# Altium schematic sheet 超级电容V2.SchDoc
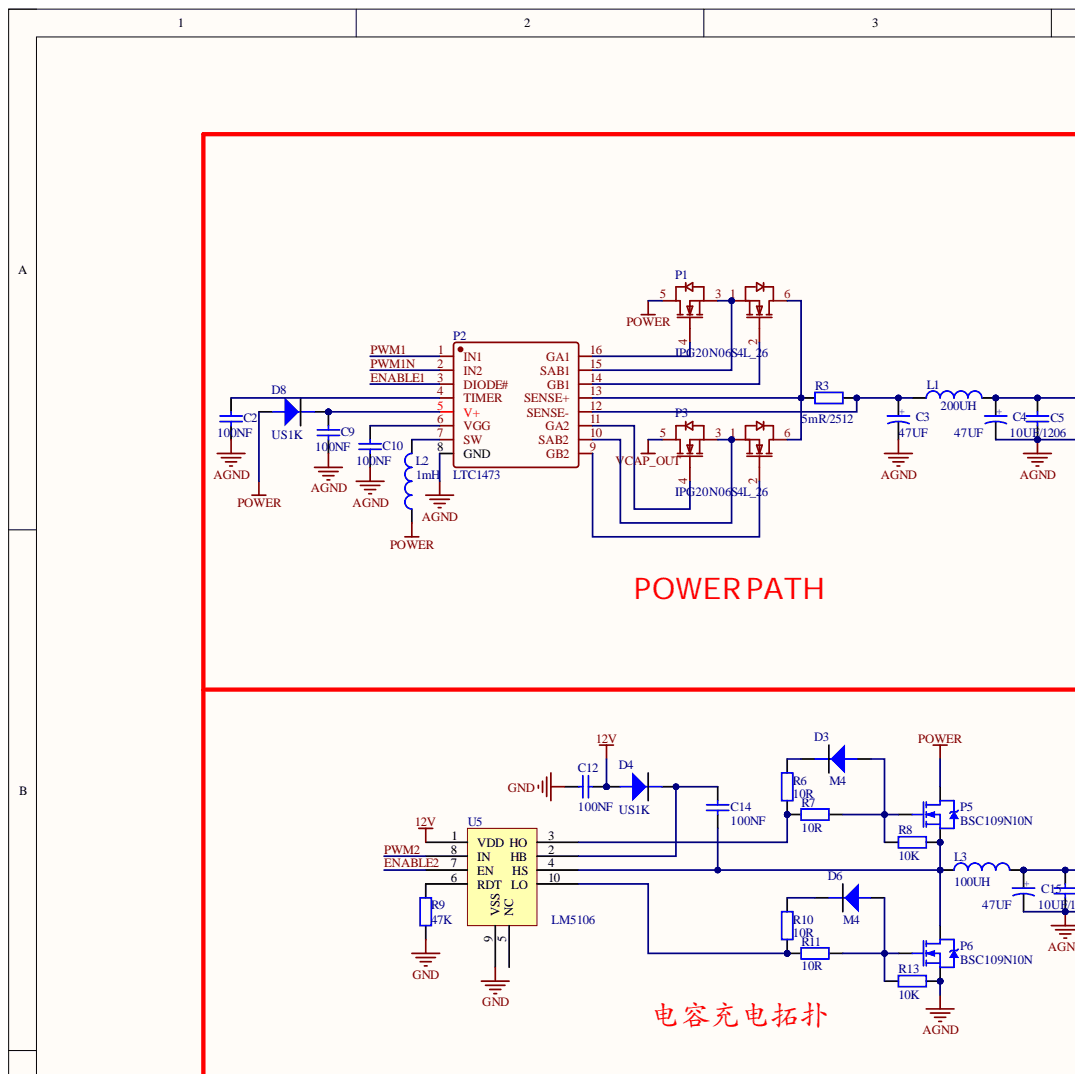
[diagram p0: 超级电容V2.SchDoc, top left]
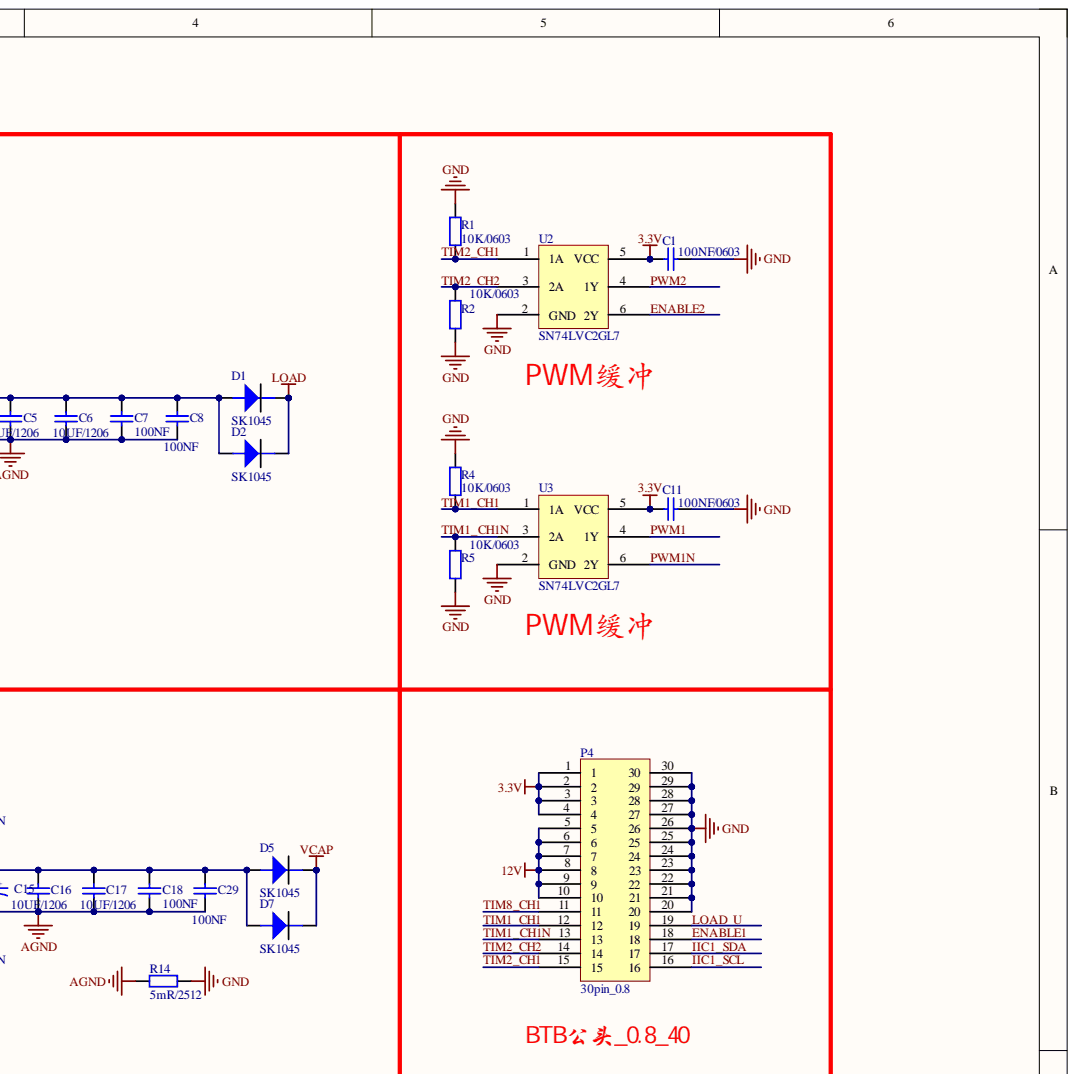
[diagram p1: 超级电容V2.SchDoc, top right]
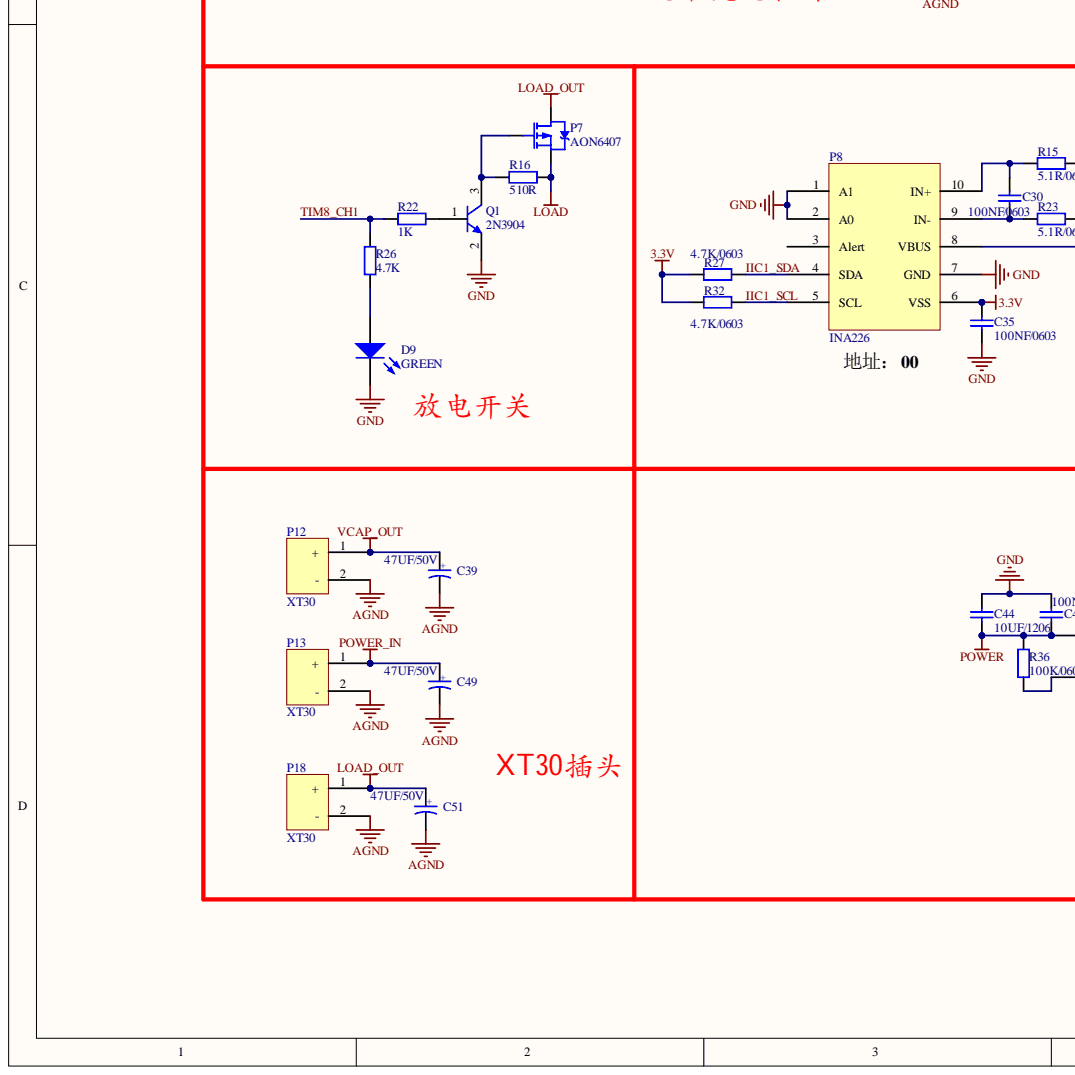
[diagram p2: 超级电容V2.SchDoc, bottom left]
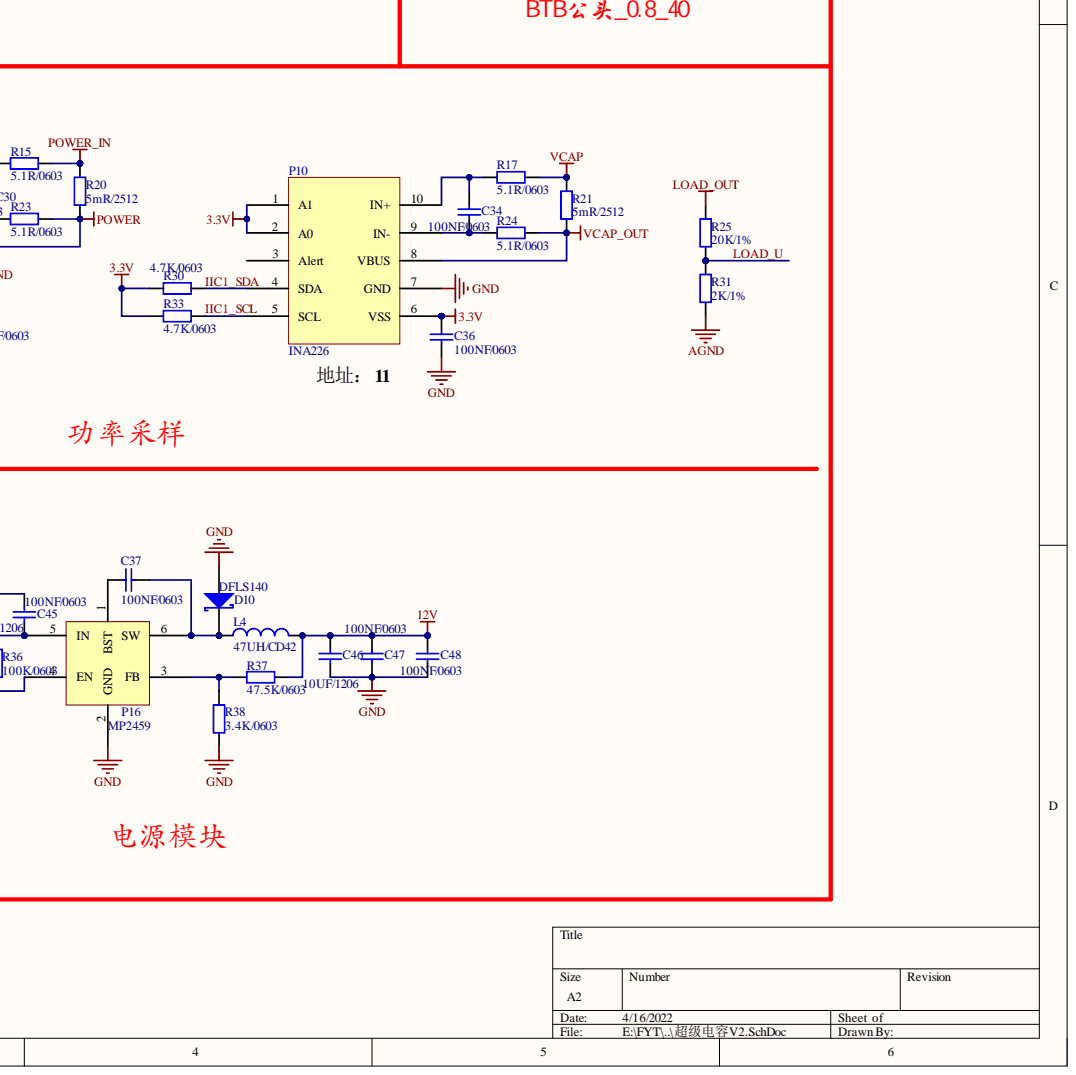
[diagram p3: 超级电容V2.SchDoc, bottom right]

## Parts
C1  100NF/0603  [C_0603]
C2  100NF  [C_0603]
C3  47UF  [C_1206]
C4  47UF  [C_1206]
C5  10UF/1206  [C_1206]
C6  10UF/1206  [C_1206]
C7  100NF  [C_0603]
C8  100NF  [C_0603]
C9  100NF  [C_0603]
C10  100NF  [C_0603]
C11  100NF/0603  [C_0603]
C12  100NF  [C_0603]
C14  100NF  [C_0603]
C15  47UF  [C_1206]
C16  10UF/1206  [C_1206]
C17  10UF/1206  [C_1206]
C18  100NF  [C_0603]
C29  100NF  [C_0603]
C30  100NF/0603  [C_0603]
C34  100NF/0603  [C_0603]
C35  100NF/0603  [C_0603]
C36  100NF/0603  [C_0603]
C37  100NF/0603  [C_0603]
C39  47UF/50V  [C-电解电容]
C44  10UF/1206  [C_1206]
C45  100NF/0603  [C_0603]
C46  10UF/1206  [C_1206]
C47  100NF/0603  [C_0603]
C48  100NF/0603  [C_0603]
C49  47UF/50V  [C-电解电容]
C51  47UF/50V  [C-电解电容]
D1  SK1045  [SK1045]
D2  SK1045  [SK1045]
D3  M4  [D_M4]
D4  US1K  [D-SMA]
D5  SK1045  [SK1045]
D6  M4  [D_M4]
D7  SK1045  [SK1045]
D8  US1K  [D-SMA]
D9  GREEN  [LED_0603]
D10  DFLS140  [D-SMA]
L1  200UH  [L_17X17]
L2  1mH  [L_1206]
L3  100UH  [L_17X17]
L4  47UH/CD42  [CD42]
P1  IPG20N06S4L_26  [IPG20N06S4L_26]
P2  LTC1473  [LTC1473]
P3  IPG20N06S4L_26  [IPG20N06S4L_26]
P4  30pin_0.8  [30PIN_0.8]
P5  BSC109N10N  [BSC109N10NS3G]
P6  BSC109N10N  [BSC109N10NS3G]
P7  AON6407  [BSC109N10NS3G]
P8  INA226  [INA226]
P10  INA226  [INA226]
P12  XT30  [30/卧式母]
P13  XT30  [30/卧式母]
P16  MP2459  [MP2459]
P18  XT30  [XT30卧母]
Q1  2N3904  [SOT-23_3]
R1  10K/0603  [R_0603]
R2  10K/0603  [R_0603]
R3  5mR/2512  [R_2512]
R4  10K/0603  [R_0603]
R5  10K/0603  [R_0603]
R6  10R  [R_0603]
R7  10R  [R_0603]
R8  10K  [R_0603]
R9  47K  [R_0603]
R10  10R  [R_0603]
R11  10R  [R_0603]
R13  10K  [R_0603]
R14  5mR/2512  [R_2512]
R15  5.1R/0603  [R_0603]
R16  510R  [R_0603]
R17  5.1R/0603  [R_0603]
R20  5mR/2512  [R_2512]
R21  5mR/2512  [R_2512]
R22  1K  [R_0603]
R23  5.1R/0603  [R_0603]
R24  5.1R/0603  [R_0603]
R25  20K/1%  [R_0603]
R26  4.7K  [R_0603]
R27  4.7K/0603  [R_0603]
R30  4.7K/0603  [R_0603]
R31  2K/1%  [R_0603]
R32  4.7K/0603  [R_0603]
R33  4.7K/0603  [R_0603]
R36  100K/0603  [R_0603]
R37  47.5K/0603  [R_0603]
R38  3.4K/0603  [R_0603]
U2  SN74LVC2GL7  [SN74LVC2GL7]
U3  SN74LVC2GL7  [SN74LVC2GL7]
U5  LM5106  [LM5106]

## Nets
3.3V: C1.1 C11.1 C35.1 C36.1 P4.1 P4.2 P4.3 P4.4 P8.6(VSS) P10.1(A1) P10.2(A0) P10.6(VSS) R27.1 R30.1 R32.1 R33.1 U2.5(VCC) U3.5(VCC)
12V: C12.1 C46.2 C47.2 C48.2 D4.2 L4.2 P4.5 P4.6 P4.7 P4.8 P4.9 P4.10 R37.1 U5.1(VDD)
AGND: C2.1 C3.2 C4.2 C5.1 C6.1 C7.1 C8.1 C9.1 C10.1 C15.2 C16.1 C17.1 C18.1 C29.1 C39.2 C49.2 C51.2 P2.8(GND) P6.3 P12.2 P13.2 P18.2 R13.2 R14.2 R31.1
GND: C1.2 C11.2 C12.2 C35.2 C36.2 C44.2 C45.2 C46.1 C47.1 C48.1 D9.2 D10.2 P4.20 P4.21 P4.22 P4.23 P4.24 P4.25 P4.26 P4.27 P4.28 P4.29 P4.30 P8.1(A1) P8.2(A0) P8.7(GND) P10.7(GND) P16.2(GND) Q1.2 R1.2 R2.1 R4.2 R5.1 R9.1 R14.1 R38.1 U2.2(GND) U3.2(GND) U5.9(VSS)
LOAD: D1.1 D2.1 P7.3 R16.2
LOAD_OUT: C51.1 P7.2 P18.1 R25.2
POWER: C44.1 C45.1 D8.2 L2.1 P1.5 P5.2 P8.8(VBUS) P16.5(IN) R20.1 R23.2 R36.2
POWER_IN: C49.1 P13.1 R15.2 R20.2
VCAP: D5.1 D7.1 R17.2 R21.2
VCAP_OUT: C39.1 P3.5 P10.8(VBUS) P12.1 R21.1 R24.2
ENABLE1: P2.3(DIODE#) P4.18
ENABLE2: U2.6(2Y) U5.7(EN)
IIC1_SCL: P4.16 P8.5(SCL) P10.5(SCL) R32.2 R33.2
IIC1_SDA: P4.17 P8.4(SDA) P10.4(SDA) R27.2 R30.2
LOAD_U: P4.19 R25.1 R31.2
PWM1: P2.1(IN1) U3.4(1Y)
PWM1N: P2.2(IN2) U3.6(2Y)
PWM2: U2.4(1Y) U5.8(IN)
TIM1_CH1: P4.12 R4.1 U3.1(1A)
TIM1_CH1N: P4.13 R5.2 U3.3(2A)
TIM2_CH1: P4.15 R1.1 U2.1(1A)
TIM2_CH2: P4.14 R2.2 U2.3(2A)
TIM8_CH1: P4.11 R22.1 R26.2
NetC2_2: C2.2 P2.4(TIMER)
NetC3_1: C3.1 L1.1 P2.12(SENSE-) R3.1
NetC4_1: C4.1 C5.2 C6.2 C7.2 C8.2 D1.2 D2.2 L1.2
NetC9_2: C9.2 D8.1 P2.5(V+)
NetC10_2: C10.2 P2.6(VGG)
NetC14_1: C14.1 L3.1 P5.3 P6.2 R8.2 U5.4(HS)
NetC14_2: C14.2 D4.1 U5.2(HB)
NetC15_1: C15.1 C16.2 C17.2 C18.2 C29.2 D5.2 D7.2 L3.2
NetC30_1: C30.1 P8.10(IN+) R15.1
NetC30_2: C30.2 P8.9(IN-) R23.1
NetC34_1: C34.1 P10.10(IN+) R17.1
NetC34_2: C34.2 P10.9(IN-) R24.1
NetC37_1: C37.1 D10.1 L4.1 P16.6(SW)
NetC37_2: C37.2 P16.1(BST)
NetD3_1: D3.1 R6.2
NetD3_2: D3.2 P5.1 R7.2 R8.1
NetD6_1: D6.1 R10.2
NetD6_2: D6.2 P6.1 R11.2 R13.1
NetD9_1: D9.1 R26.1
NetL2_2: L2.2 P2.7(SW)
NetP1_1: P1.1 P1.3 P2.15(SAB1)
NetP1_2: P1.2 P2.14(GB1)
NetP1_4: P1.4 P2.16(GA1)
NetP1_6: P1.6 P2.13(SENSE+) P3.6 R3.2
NetP2_9: P2.9(GB2) P3.2
NetP2_10: P2.10(SAB2) P3.1 P3.3
NetP2_11: P2.11(GA2) P3.4
NetP7_1: P7.1 Q1.3 R16.1
NetP16_3: P16.3(FB) R37.2 R38.2
NetP16_4: P16.4(EN) R36.1
NetQ1_1: Q1.1 R22.2
NetR6_1: R6.1 R7.1 U5.3(HO)
NetR9_2: R9.2 U5.6(RDT)
NetR10_1: R10.1 R11.1 U5.10(LO)

Not connected: P8 3(Alert); P10 3(Alert); U5 5(NC)

## Library data of the parts
C1 C2 C5-C12 C14 C16-C18 C29 C30 C34-C37 C44-C48 = Cap1: 贴片电容; Component Kind: Standard; Library Name: 党亚宁的库.SchLib
C3 C4 C15 C39 C49 C51 = Cap2: 电解电容; Component Kind: Standard; Library Name: 党亚宁的库.SchLib
D1-D8 = Diode: 普通二极管; Component Kind: Standard; Library Name: 党亚宁的库.SchLib
D9 = LED: 发光二极管; Component Kind: Standard; Library Name: 党亚宁的库.SchLib
D10 = Diode_SCHOTTKY: 肖特基二极管; Component Kind: Standard; Library Name: 党亚宁的库.SchLib
L1-L4 = L: 贴片电感; Component Kind: Standard; Library Name: 党亚宁的库.SchLib
P5 P6 = MOS_N: Component Kind: Standard; Library Name: 党亚宁的库.SchLib
P7 = PMOS: Component Kind: Standard; Library Name: 党亚宁的库.SchLib
P12 P13 P18 = XT60: Component Kind: Standard; Library Name: 党亚宁的库.SchLib
Q1 = NPN: Component Kind: Standard; Library Name: 党亚宁的库.SchLib
R1-R11 R13-R17 R20-R27 R30-R33 R36-R38 = Res1: 贴片电阻; Component Kind: Standard; Library Name: 党亚宁的库.SchLib
P1 P3 = IPG20N06S4L_26: Component Kind: Standard; Library Name: 党亚宁的库.SchLib
P2 = LTC1473: Component Kind: Standard; Library Name: 党亚宁的库.SchLib
P4 = 30pin_0.8: Component Kind: Standard; Library Name: 党亚宁的库.SchLib
P8 P10 = INA226: Component Kind: Standard; Library Name: 党亚宁的库.SchLib
P16 = MP2459: Component Kind: Standard; Library Name: 党亚宁的库.SchLib
U2 U3 = SN74LVC2GL7: Component Kind: Standard; Library Name: 党亚宁的库.SchLib
U5 = LM5106: Component Kind: Standard; Library Name: 党亚宁的库.SchLib
